# Altium schematic sheet Comparator.SchDoc
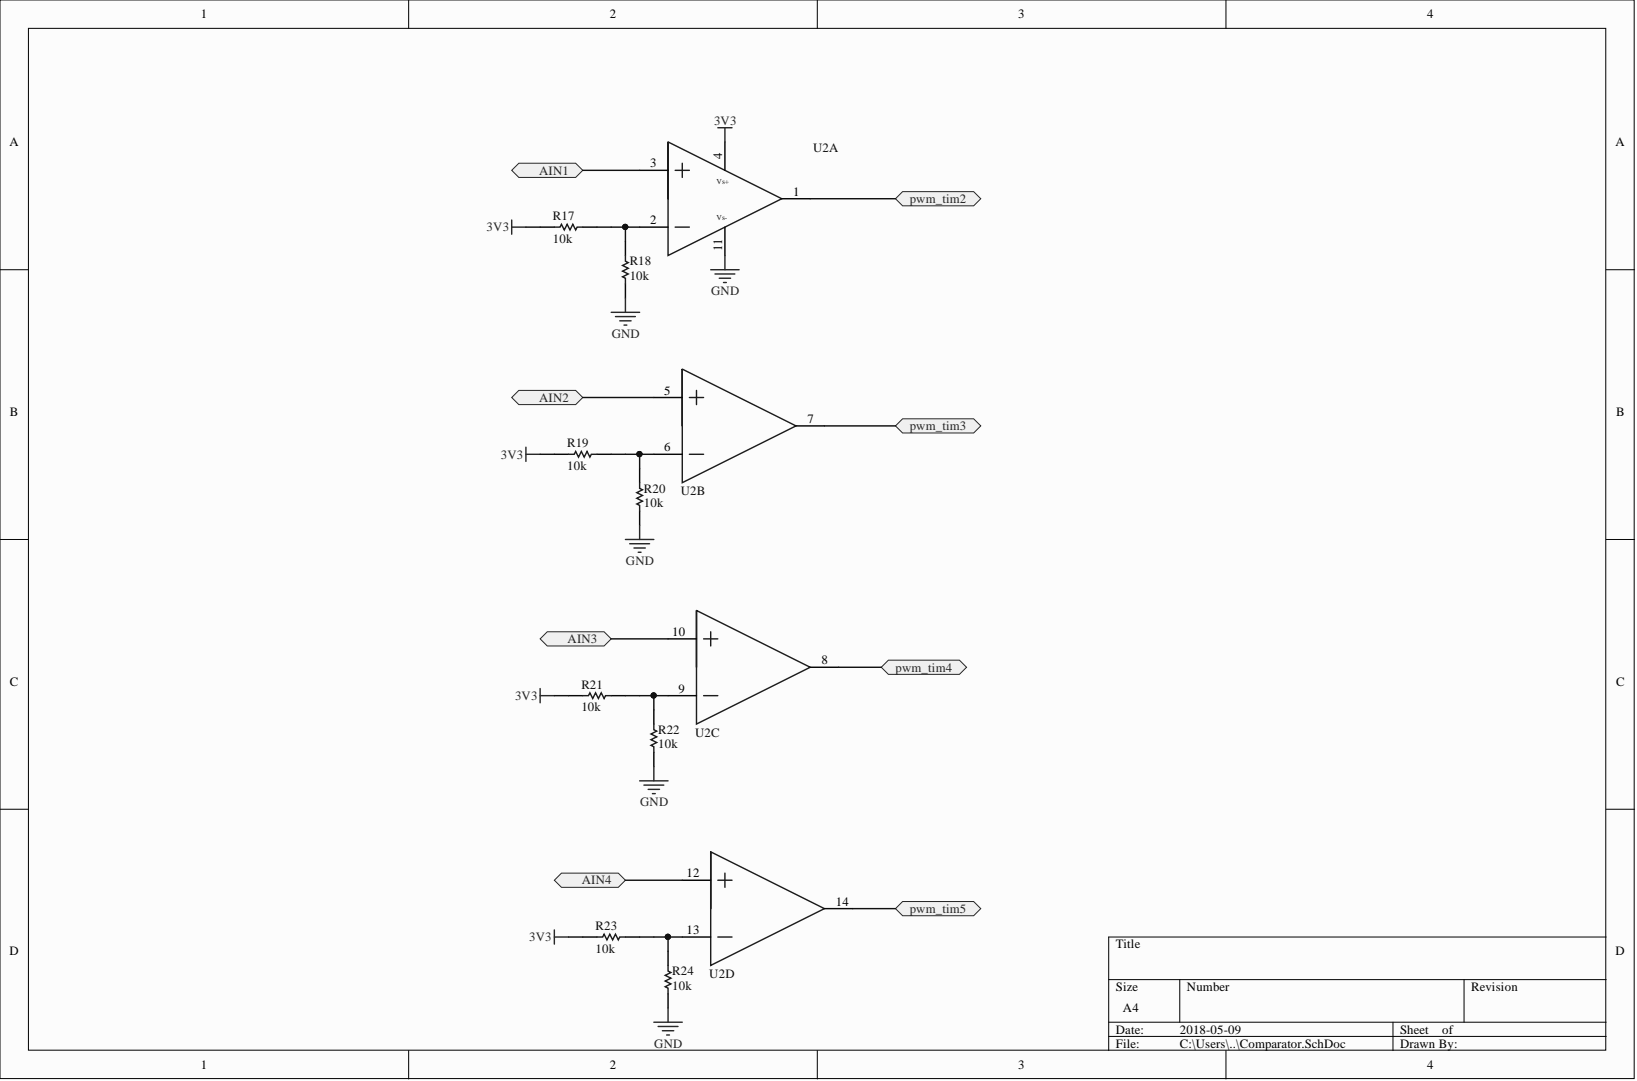
[diagram p0: Comparator.SchDoc]

## Parts
R17  10k  [RESC1608N(0603")]
R18  10k  [RESC1608N(0603")]
R19  10k  [RESC1608N(0603")]
R20  10k  [RESC1608N(0603")]
R21  10k  [RESC1608N(0603")]
R22  10k  [RESC1608N(0603")]
R23  10k  [RESC1608N(0603")]
R24  10k  [RESC1608N(0603")]
U2  Quad Op Amp TSV324  [SOP-14]  (value not drawn)  (parts A,B,C,D)

## Ports
AIN1, AIN2, AIN3, AIN4, pwm_tim2, pwm_tim3, pwm_tim4, pwm_tim5

## Nets
3V3: R17.2 R19.2 R21.2 R23.2 U2.4
GND: R18.2 R20.2 R22.2 R24.2 U2.11
AIN1: U2.3 ; port AIN1
AIN2: U2.5 ; port AIN2
AIN3: U2.10 ; port AIN3
AIN4: U2.12 ; port AIN4
pwm_tim2: U2.1 ; port pwm_tim2
pwm_tim3: U2.7 ; port pwm_tim3
pwm_tim4: U2.8 ; port pwm_tim4
pwm_tim5: U2.14 ; port pwm_tim5
NetR17_1: R17.1 R18.1 U2.2
NetR19_1: R19.1 R20.1 U2.6
NetR21_1: R21.1 R22.1 U2.9
NetR23_1: R23.1 R24.1 U2.13

## Library data of the parts
R17-R24 = 10kR, 1%, 1/10W, 0603 SMD: Made By: Ashwin Sira; Owned By: AUVic; Component Kind: Standard; Created On: 14-05-31; Description: 10kR, 1%, 1/10W, 0603 SMD; Last Edited: 14-05-31; Library Name: AUVic_Integrated_Library_Resistors.IntLib; Supplier 1: Digi-Key; Supplier Part Number 1: 311-10.0KHRCT-ND; Value: 10k
U2 = Quad Op Amp TSV324: TSV324; Component Kind: Standard; Creation Date: 10/14/2016; LatestRevisionNote: Re-released for DXP Platform.; Supplier: Digikey; Supplier Part Number: 497-8198-2-ND; Created By: Jordan; Library Name: AUVic_Integrated_Library_Op_amps.IntLib; Publisher: Altium Limited
